# Altium schematic sheet MAX-3B_1.SchDoc(MAX-3B_1)
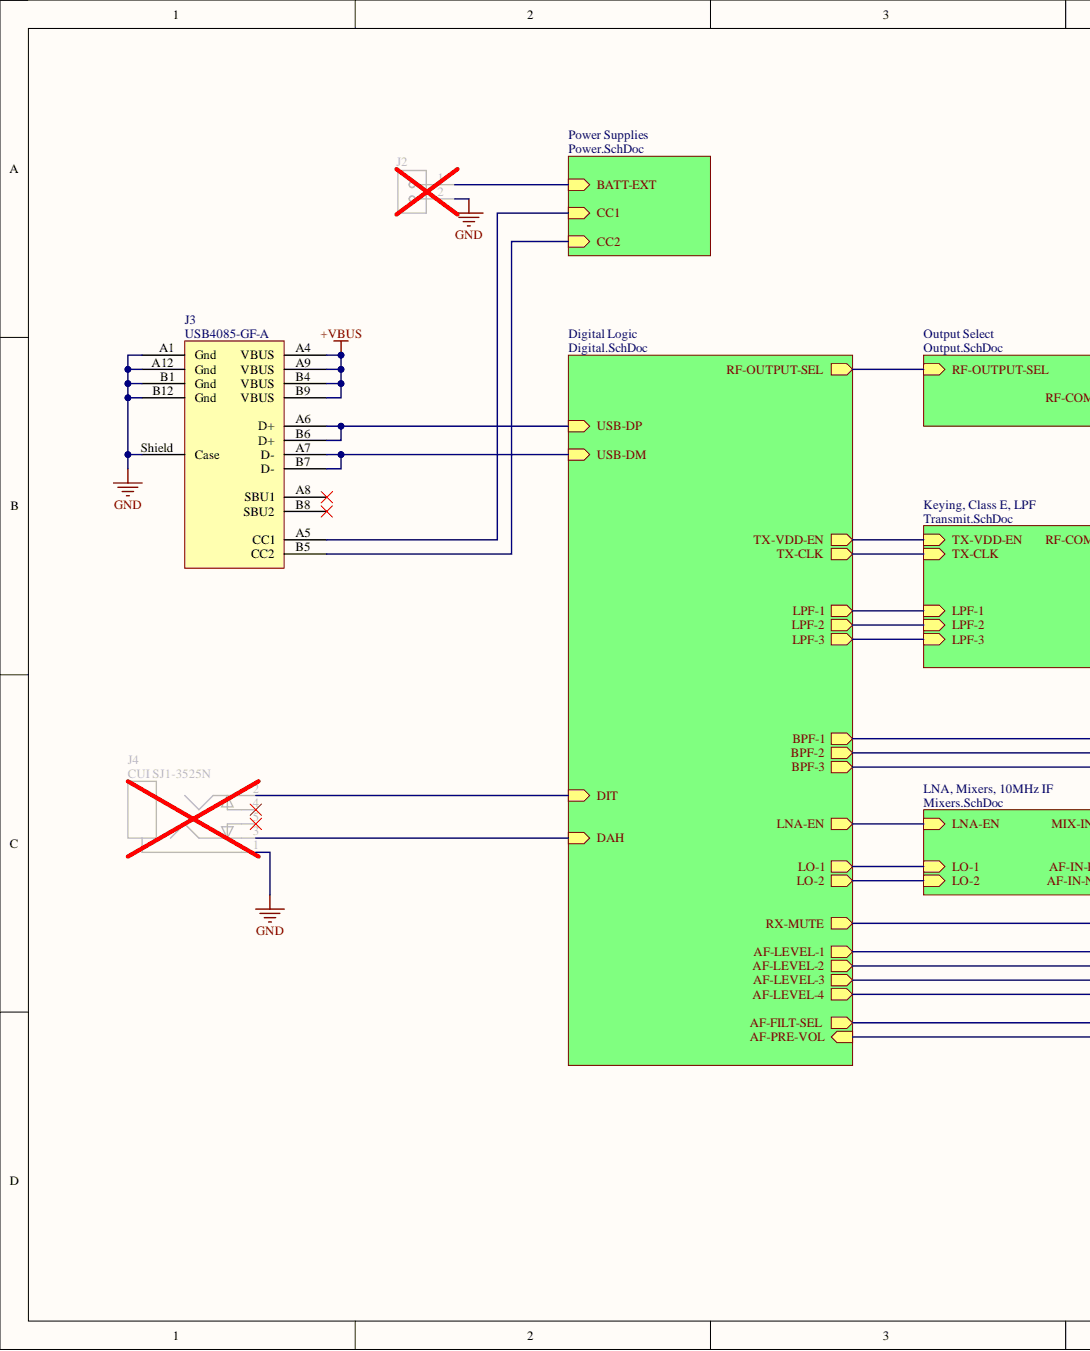
[diagram p0: MAX-3B_1.SchDoc, left]
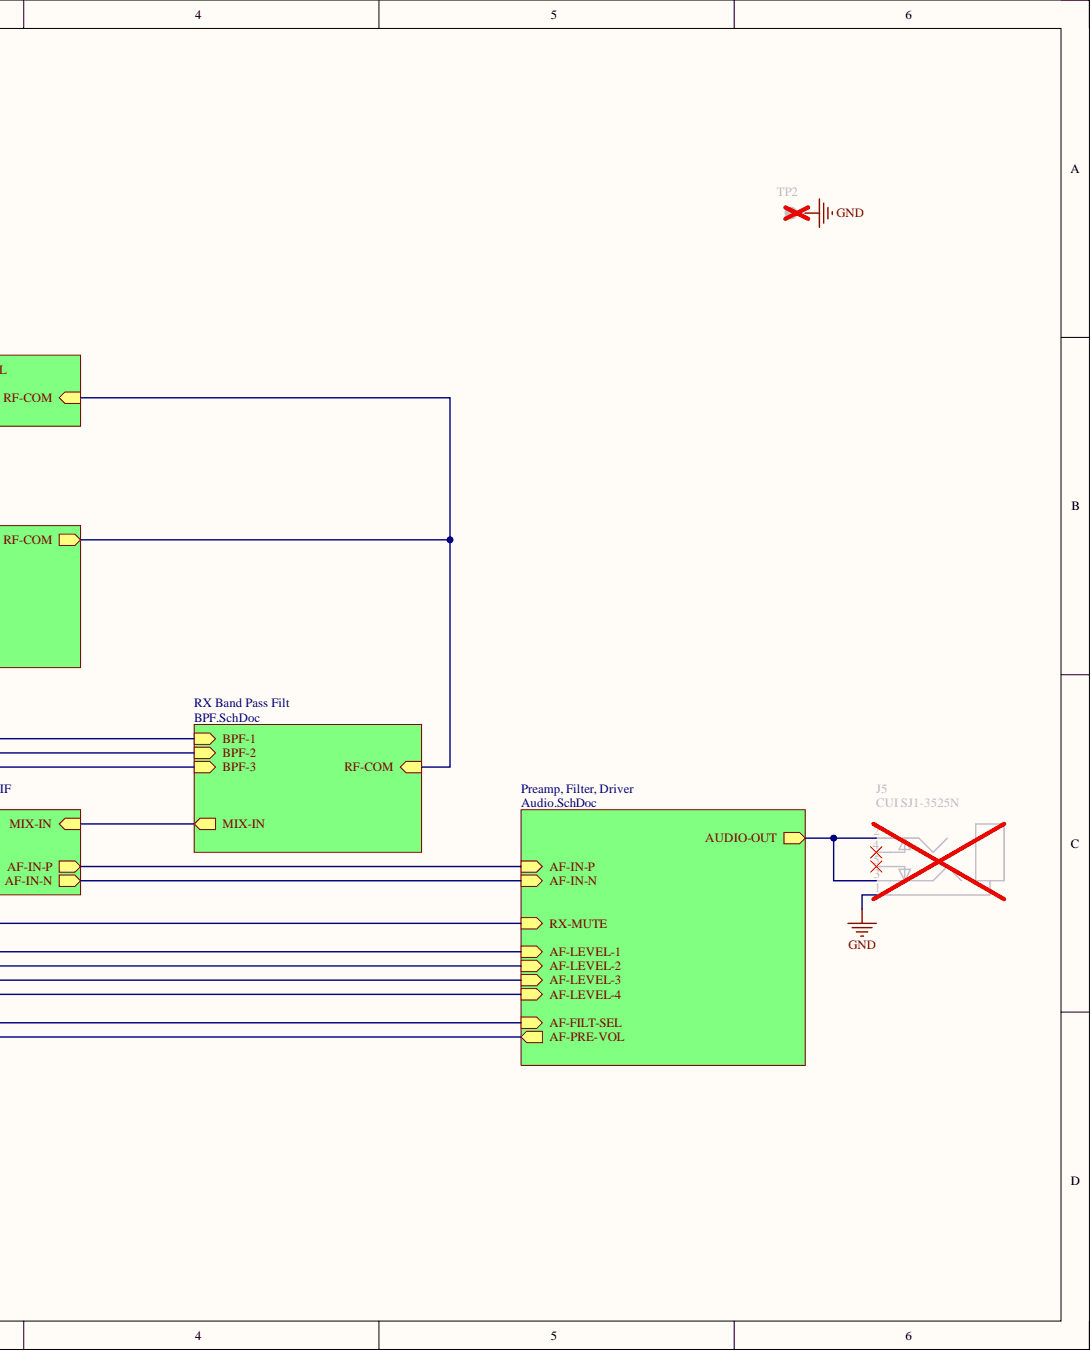
[diagram p1: MAX-3B_1.SchDoc, right]

## Parts
J2  Molex 22-28-8020  [PCB-j8pg0b45ipj0ijuf1way-1]  (value not drawn)
J3  Manufacturer Part Number: USB4085-GF-A  [USB 2.0 Type C]  (hidden comment: Global Connector Technology USB4085-GF-A)
J4  CUI SJ1-3525N  Manufacturer Part Number: SJ1-3525N  [PCB-az1s74rdeu0ip3wc0cnm-2]
J5  CUI SJ1-3525N  Manufacturer Part Number: SJ1-3525N  [PCB-az1s74rdeu0ip3wc0cnm-2]
TP2  Keystone 5006  [PCB-ooooas9k4rkfcqhrig9c-1]  (value not drawn)

## Nets
+VBUS: J3.A4(VBUS) J3.A9(VBUS) J3.B4(VBUS) J3.B9(VBUS)
GND: J2.2 J3.A1(Gnd) J3.A12(Gnd) J3.B1(Gnd) J3.B12(Gnd) J3.Shield(Case) J4.1 J5.1 TP2.1
NetJ2_1: J2.1
NetJ3_A5: J3.A5(CC1)
NetJ3_A6: J3.A6(D+) J3.B6(D+)
NetJ3_A7: J3.A7(D-) J3.B7(D-)
NetJ3_A8: J3.A8(SBU1)
NetJ3_B5: J3.B5(CC2)
NetJ3_B8: J3.B8(SBU2)
NetJ4_2: J4.2
NetJ4_3: J4.3
NetJ4_4: J4.4
NetJ4_5: J4.5
NetJ5_2: J5.2 J5.3
NetJ5_4: J5.4
NetJ5_5: J5.5
AF-FILT-SEL:
AF-IN-N:
AF-IN-P:
AF-LEVEL-1:
AF-LEVEL-2:
AF-LEVEL-3:
AF-LEVEL-4:
AF-PRE-VOL:
BPF-1:
BPF-2:
BPF-3:
LNA-EN:
LO-1:
LO-2:
LPF-1:
LPF-2:
LPF-3:
MIX-IN:
RF-COM:
RF-OUTPUT-SEL:
RX-MUTE:
TX-CLK:
TX-VDD-EN:

## Library data of the parts
J2 = CMP-fe0d37c71f5121ca-2: Conn Unshrouded Header HDR 2 POS 2.54mm Solder RA Thru-Hole KKR Bag; Current Rating: 4.00 A; Gender: Male; Glow Wire Compliant: Non-Compliant; Lead-Free Status: Lead Free; Number of Circuits: 2, 36; Number of Contacts: 2; Voltage Rating (DC): 250 V; Color: Black; Component Kind: Standard; Contact Material: Phosphor Bronze; Contact Plating: Tin; Flammability Rating: UL 94 V-0; Halogen Free Status: Not Low Halogen; Housing Color: Black; Housing Material: Nylon; Library Name: My Personal Space; Lifecycle Status: Active; Manufacturer Part Number: 22-28-8020; Mounting Style: Through Hole, Surface Mount; Number of Positions: 2; Number of Rows: 1; Octopart URL: https://octopart.com/22-28-8020-molex-131383; OctopartID: d9cd10c5fd9c060a; Operating Temperature: 75.0 °C (max); Orientation: Right Angle; Packaging: Bulk; Pin Pitch: 2.54 mm; RoHS: Compliant; Size-Height: 2.49 mm; Size-Length: 5.08 mm; Size-Width: 2.29 mm
J3 = CMP-a93f6593ad9a76f8-4: USB4085 Series 20 V PCB Top Mount USB 2.0 Type C Receptacle; Gender: Female; Lead-Free Status: Lead Free; Component Kind: Standard; Contact Material: Copper Alloy; Library Name: CommunityVault
J4 J5 = CMP-ca40034985531587-9: 3.5 mm Right Angle Through Hole Tip and Ring Switch Audio Jack; Gender: Female; Lead-Free Status: Lead Free; Mounting angle: 90; Shielding: No; Color: Black; Component Kind: Standard; Contact Plating: Silver; Library Name: My Personal Space; Lifecycle Status: Active; Mounting Style: Through Hole; Octopart URL: http://octopart.com/sj1-3525n-cui-223861; OctopartID: ca40034985531587; Orientation: Horizontal; Packaging: Bulk; RoHS: Compliant; Size-Diameter: 3.50 mm
TP2 = CMP-eb34223ee69c34f3-24: PCB Test Point 0.07 in. I.D. x 0.22 in. Ht. Terminal Black Valox Base; Manufacturer Part Number: 5006; Lead-Free Status: Lead Free; Color: Black; Component Kind: Standard; Contact Material: Phosphor Bronze; Contact Plating: Tin, Silver; Library Name: My Personal Space; Material: Aluminum; Mounting Style: Through Hole, PC Board, PCB; Octopart URL: https://octopart.com/5006-keystone-10446; OctopartID: eb34223ee69c34f3; Packaging: Bulk; REACH SVHC Compliance: No SVHC; RoHS: Compliant; Size-Diameter: 3.18 mm; Size-Height: 5.59 mm; Size-Inner Diameter: 1.78 mm; Size-Length: 8.89 mm
